# Altium schematic sheet VoltageSensorBoard.SchDoc(VoltageSensorBoard)
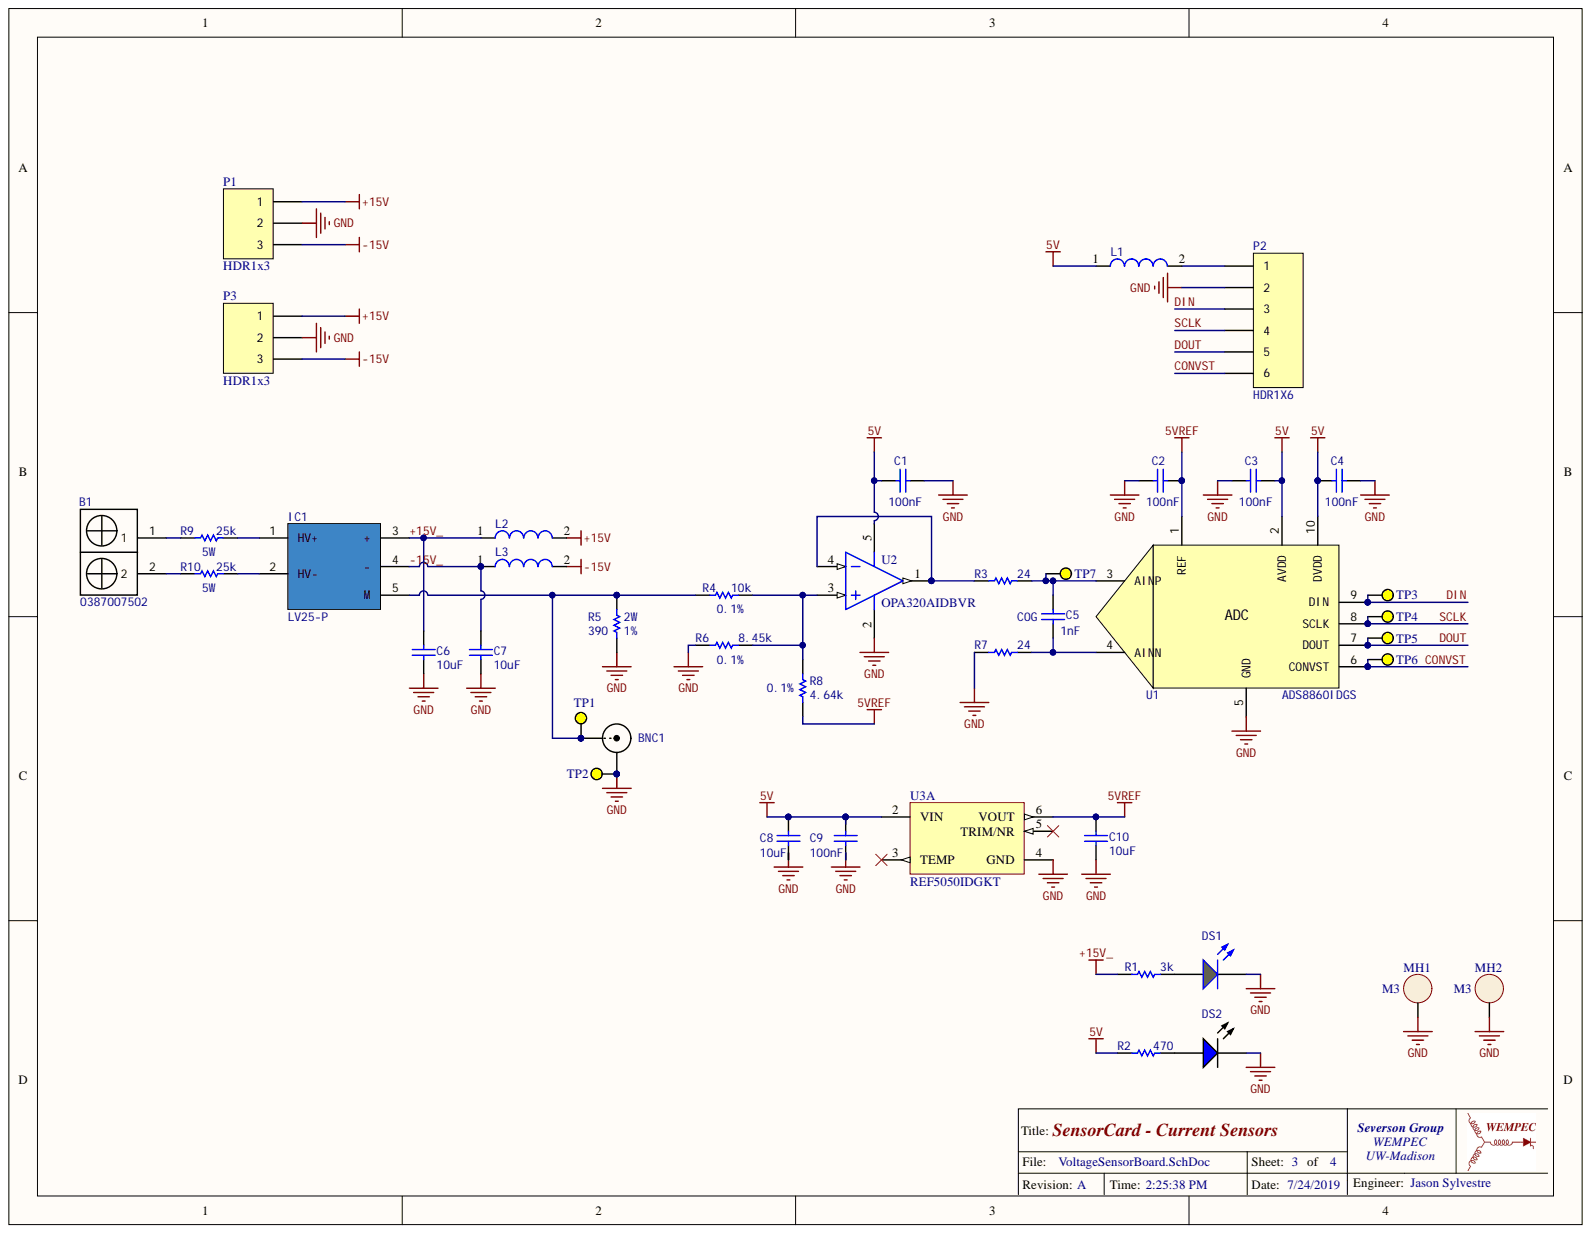
[diagram p0: VoltageSensorBoard.SchDoc]

## Parts
*1  TitleBlockWEMPEC  (value not drawn)
B1  Manufacturer Part Number: 0387007502  [BARRIER_STRIP]  (hidden comment: CON_BARRIER_STRIP)
BNC1  BNC  [BNC]
C1  Capacitance: 100nF  [CAPC1608X87X35NL15T15]  (hidden comment: C0603C104Z3VACTU)
C2  Capacitance: 100nF  [CAPC1608X87X35NL15T15]  (hidden comment: C0603C104Z3VACTU)
C3  Capacitance: 100nF  [CAPC1608X87X35NL15T15]  (hidden comment: C0603C104Z3VACTU)
C4  Capacitance: 100nF  [CAPC1608X87X35NL15T15]  (hidden comment: C0603C104Z3VACTU)
C5  Type (Capacitors): C0G  Capacitance: 1nF  [CAPC1608X90X35ML10T15]  (hidden comment: GRM1885C1H102JA01D)
C6  Capacitance: 10uF  [CAP-0805]  (hidden comment: Cap)
C7  Capacitance: 10uF  [CAP-0805]  (hidden comment: Cap)
C8  Capacitance: 10uF  [CAP-0805]  (hidden comment: Cap)
C9  Capacitance: 100nF  [CAPC1608X87X35NL15T15]  (hidden comment: C0603C104Z3VACTU)
C10  Capacitance: 10uF  [CAP-0805]  (hidden comment: Cap)
DS1  LTST-C193KGKT-5A  [LTST-C193KGKT-5A_V]  (value not drawn)
DS2  LTST-C193TBKT-5A  [LTST-C193TBKT_V]  (value not drawn)
IC1  LV25-P  [LV 25-P]
L1  742792609  [SMD-0603]  (value not drawn)
L2  742792609  [SMD-0603]  (value not drawn)
L3  742792609  [SMD-0603]  (value not drawn)
MH1  M3  [MOUNTING HOLE - M3]
MH2  M3  [MOUNTING HOLE - M3]
P1  HDR1x3  [Term Block - 3 pos]
P2  HDR1X6  [HDR1x6]
P3  HDR1x3  [HDR1x3]
R1  Resistance: 3k  [RESC1608X55X30LL15T15]  (hidden comment: ERJ-3GEYJ302V)
R2  RC0603FR-07470RL  [RESC1608X55X25LL10T15]  (value not drawn)
R3  ERJ-3GEYJ240V  [RESC1608X55X30NL15T15]  (value not drawn)
R4  Tolerance: 0.1%  Resistance: 10k  [RESC1608X55X30ML20T20]  (hidden comment: ERA-3AEB103V)
R5  Tolerance: 1%  Power Rating: 2W  [RES-2512]  (hidden comment: Res 0603)
R6  Tolerance: 0.1%  Resistance: 8.45k  [RESC1608X55X30ML20T20]  (hidden comment: ERA3AEB8451V)
R7  ERJ-3GEYJ240V  [RESC1608X55X30NL15T15]  (value not drawn)
R8  Tolerance: 0.1%  Resistance: 4.64k  [RESC1608X55X30LL20T20]  (hidden comment: ERA3AEB4641V)
R9  Resistance: 25k  Power Rating: 5W  [Axial_5W]  (hidden comment: Res TH)
R10  Resistance: 25k  Power Rating: 5W  [Axial_5W]  (hidden comment: Res TH)
TP1  5004  [KSTN-5004]  (value not drawn)
TP2  5004  [KSTN-5004]  (value not drawn)
TP3  5004  [KSTN-5004]  (value not drawn)
TP4  5004  [KSTN-5004]  (value not drawn)
TP5  5004  [KSTN-5004]  (value not drawn)
TP6  5004  [KSTN-5004]  (value not drawn)
TP7  5004  [KSTN-5004]  (value not drawn)
U1  ADC  Manufacturer Part Number: ADS8860IDGS  [VSSOP10]
U2  OPA320AIDBVR  [DBV0005A_L]
U3  REF5050IDGKT  [DGK0008A_N]  (part A)

## Nets
5V: C1.1 C3.1 C4.2 C8.2 C9.2 L1.1 R2.1 U1.2(AVDD) U1.10(DVDD) U2.5 U3.2(VIN)
5VREF: C2.1 C10.2 R8.1 U1.1(REF) U3.6(VOUT)
+15V: L2.2 P1.1 P3.1
-15V: L3.2 P1.3 P3.3
GND: BNC1.2 C1.2 C2.2 C3.2 C4.1 C6.1 C7.1 C8.1 C9.1 C10.1 DS1.2 DS2.2 MH1.1 MH2.1 P1.2 P2.2 P3.2 R5.1 R6.1 R7.1 TP2.1 U1.5(GND) U2.2 U3.4(GND)
+15V_: C6.2 IC1.3(+) L2.1 R1.1
-15V_: C7.2 IC1.4(-) L3.1
CONVST: P2.6 TP6.1 U1.6(CONVST)
DIN: P2.3 TP3.1 U1.9(DIN)
DOUT: P2.5 TP5.1 U1.7(DOUT)
SCLK: P2.4 TP4.1 U1.8(SCLK)
NetB1_1: B1.1 R9.1
NetB1_2: B1.2 R10.1
NetBNC1_1: BNC1.1 IC1.5(M) R4.1 R5.2 TP1.1
NetC5_1: C5.1 R7.2 U1.4(AINN)
NetC5_2: C5.2 R3.2 TP7.1 U1.3(AINP)
NetDS1_1: DS1.1 R1.2
NetDS2_1: DS2.1 R2.2
NetIC1_1: IC1.1(HV+) R9.2
NetIC1_2: IC1.2(HV-) R10.2
NetL1_2: L1.2 P2.1
NetR3_1: R3.1 U2.1 U2.4
NetR4_2: R4.2 R6.2 R8.2 U2.3

Not connected: U3 3(TEMP) 5(TRIM/NR)
